# Altium schematic sheet TopSheet.SchDoc(TopSheet)
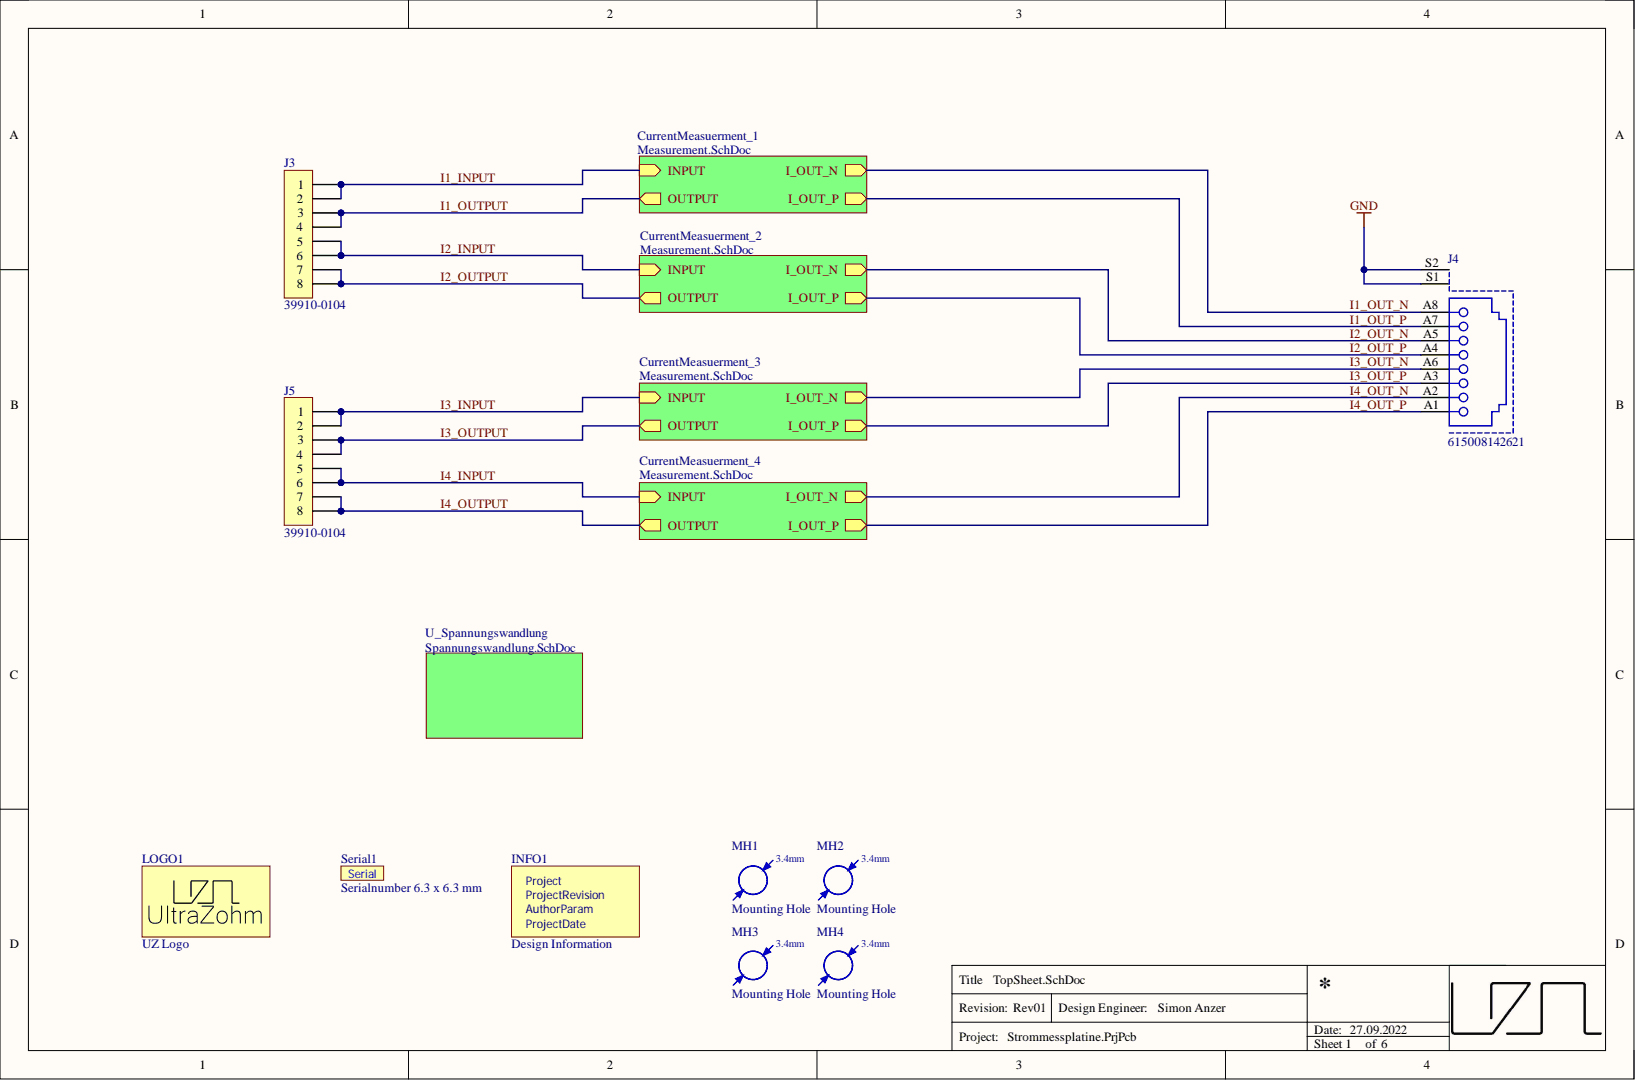
[diagram p0: TopSheet.SchDoc]

## Parts
INFO1  Design Information  [Design_info]  (no BOM)
J3  39910-0104  [39910-0104]
J4  615008142621  [615008142621]
J5  39910-0104  [39910-0104]
LOGO1  UZ Logo  value Logo  Component Type: Logo  [UZ_Logo_small]  (no BOM)
MH1  Mounting Hole  [MH_3.4]
MH2  Mounting Hole  [MH_3.4]
MH3  Mounting Hole  [MH_3.4]
MH4  Mounting Hole  [MH_3.4]
Serial1  Serialnumber 6.3 x 6.3 mm  [SERIAL_6.3X6.3MM]  (no BOM)

## Nets
GND: J4.S1 J4.S2
I1_INPUT: J3.1 J3.2
I1_OUT_N: J4.A8
I1_OUT_P: J4.A7
I1_OUTPUT: J3.3 J3.4
I2_INPUT: J3.5 J3.6
I2_OUT_N: J4.A5
I2_OUT_P: J4.A4
I2_OUTPUT: J3.7 J3.8
I3_INPUT: J5.1 J5.2
I3_OUT_N: J4.A6
I3_OUT_P: J4.A3
I3_OUTPUT: J5.3 J5.4
I4_INPUT: J5.5 J5.6
I4_OUT_N: J4.A2
I4_OUT_P: J4.A1
I4_OUTPUT: J5.7 J5.8

## Library data of the parts
INFO1 = Design Information: Design InformationS; Manufacturer: UltraZohm; Created from: MGremer; Database Table Name: Misc; Component Type: Design Information; ComponentLink1Description: https://docs.ultrazohm.com/; ComponentLink1URL: homepage; Created On: 03.11.2021 09:04:23; Last Updated: 03.11.2021 09:28:34; Library Name: user.DbLib; Live Cycle Status: 1; Manufacturer Part Number: Design Information; PartID: 12; Supplier 1: -; Supplier Part Number 1: -; Type: Design Information; Value: Design Information
J3 J5 = 39910-0104: 10.16mm Beau Eurostyle Fixed Mount PCB Terminal Block High Voltage High Power 60A 4 Circuits; Manufacturer: Molex; Category: Terminal Blocks; Component Kind: Standard; ComponentLink1Description: https://datasheet.ciiva.com/5187/0399100104-terminal-blocks-167725-5187186.pdf?src-supplier=Mouser; ComponentLink1URL: https://datasheet.ciiva.com/5187/0399100104-terminal-blocks-167725-5187186.pdf?src-supplier=Mouser; Manufacturer Part Number: 39910-0104; Pricing 1: 1=14,50000, 10=12,75600, 25=12,03160, 50=11,45160, 100=11,23410, 250=9,78456, 500=9,42218, 1000=9,05979 (USD); RoHS: Yes; Stock 1: 845; Supplier 1: Digi-Key; Supplier Part Number 1: WM5966-ND; Library Name: StrommessplatineSchlib1.SchLib
J4 = 615008142621: WR-MJ; Cat5 RJ45 Modular Jack Socket; STP; Horizontal; PCB Mount 615008 Series; Manufacturer: Wurth Electronics; Current Rating: 1.5A; Direction: Down; Gender: Female; Insulation Resistance: 500MR; Number of Ports: 1; Number of Positions: 8; Shielding: Shielded; Voltage Rating (AC): 120V; Category: Connectors; Component Kind: Standard; ComponentLink1Description: https://datasheet.ciiva.com/685/615008142621-685839.pdf?src-supplier=Digi-Key; ComponentLink1URL: https://datasheet.ciiva.com/685/615008142621-685839.pdf?src-supplier=Digi-Key; Connector Type: Jack; Contact Material: Copper Alloy; Contact Plating: Gold; Flammability Rating: UL94 V-0; Housing Material: PBT; Manufacturer Part Number: 615008142621; Max Operating Temperature: 85°C; Min Operating Temperature: -40°C; Mount: Through Hole; Number of Pins: 8; Number of Rows: 1; Package Quantity: 80; Packaging: Tray; Pricing 1: 1=3,00600, 15=2,69900, 50=2,40500, 100=2,24500, 250=2,11100 (USD); RoHS: Yes; RoHS Compliant: Yes; Series: WR_COM; Stock 1: 1; Supplier 1: RSComponents; Supplier Part Number 1: 8183327; Termination: Through Hole; Weight: 0.204589oz; Library Name: StrommessplatineSchlib1.SchLib
LOGO1 = Logo small: Manufacturer: UltraZohm; Created from: MGremer; Database Table Name: Misc; ComponentLink1Description: https://docs.ultrazohm.com/; ComponentLink1URL: homepage; Created On: 03.11.2021 09:04:23; Description: UZ Logo; Last Updated: 03.11.2021 09:05:50; Library Name: user.DbLib; Live Cycle Status: 1; Manufacturer Part Number: Logo small; PartID: 10; Supplier 1: -; Supplier Part Number 1: -; Type: Logo; Created: Jan2021_Liegmann
MH1-MH4 = Mounting Hole: Created from: MHlatky; Database Table Name: Misc; Component Kind: Standard; Component Type: Mounting Hole; ComponentLink1Description: Datasheet; Created On: 26.01.2021 09:19:51; Description: Mounting Hole; Device Package: -; Last Updated: 21.03.2022 23:05:12; Library Name: user.DbLib; Live Cycle Status: 1; Manufacturer: Mounting Hole; Manufacturer Part Number: Mounting Hole; PartID: 16; Stock number: not stored; Supplier 1: Mounting Hole; Supplier Part Number 1: Mounting Hole; Type: Mounting Hole; Value: Mounting Hole
Serial1 = Serial Number: Manufacturer: UltraZohm; Created from: MGremer; Database Table Name: Misc; Value: REV; Component Type: Serial Number; ComponentLink1Description: https://docs.ultrazohm.com/; ComponentLink1URL: homepage; Created On: 03.11.2021 09:04:23; Description: Serial Number; Last Updated: 03.11.2021 09:28:34; Library Name: user.DbLib; Live Cycle Status: 1; Manufacturer Part Number: Serial Number; PartID: 11; Supplier 1: -; Supplier Part Number 1: -; Type: Serial Number; Created: 2018_09_18_AGeiger
